# Altium schematic sheet DIn_Isolator.SchDoc
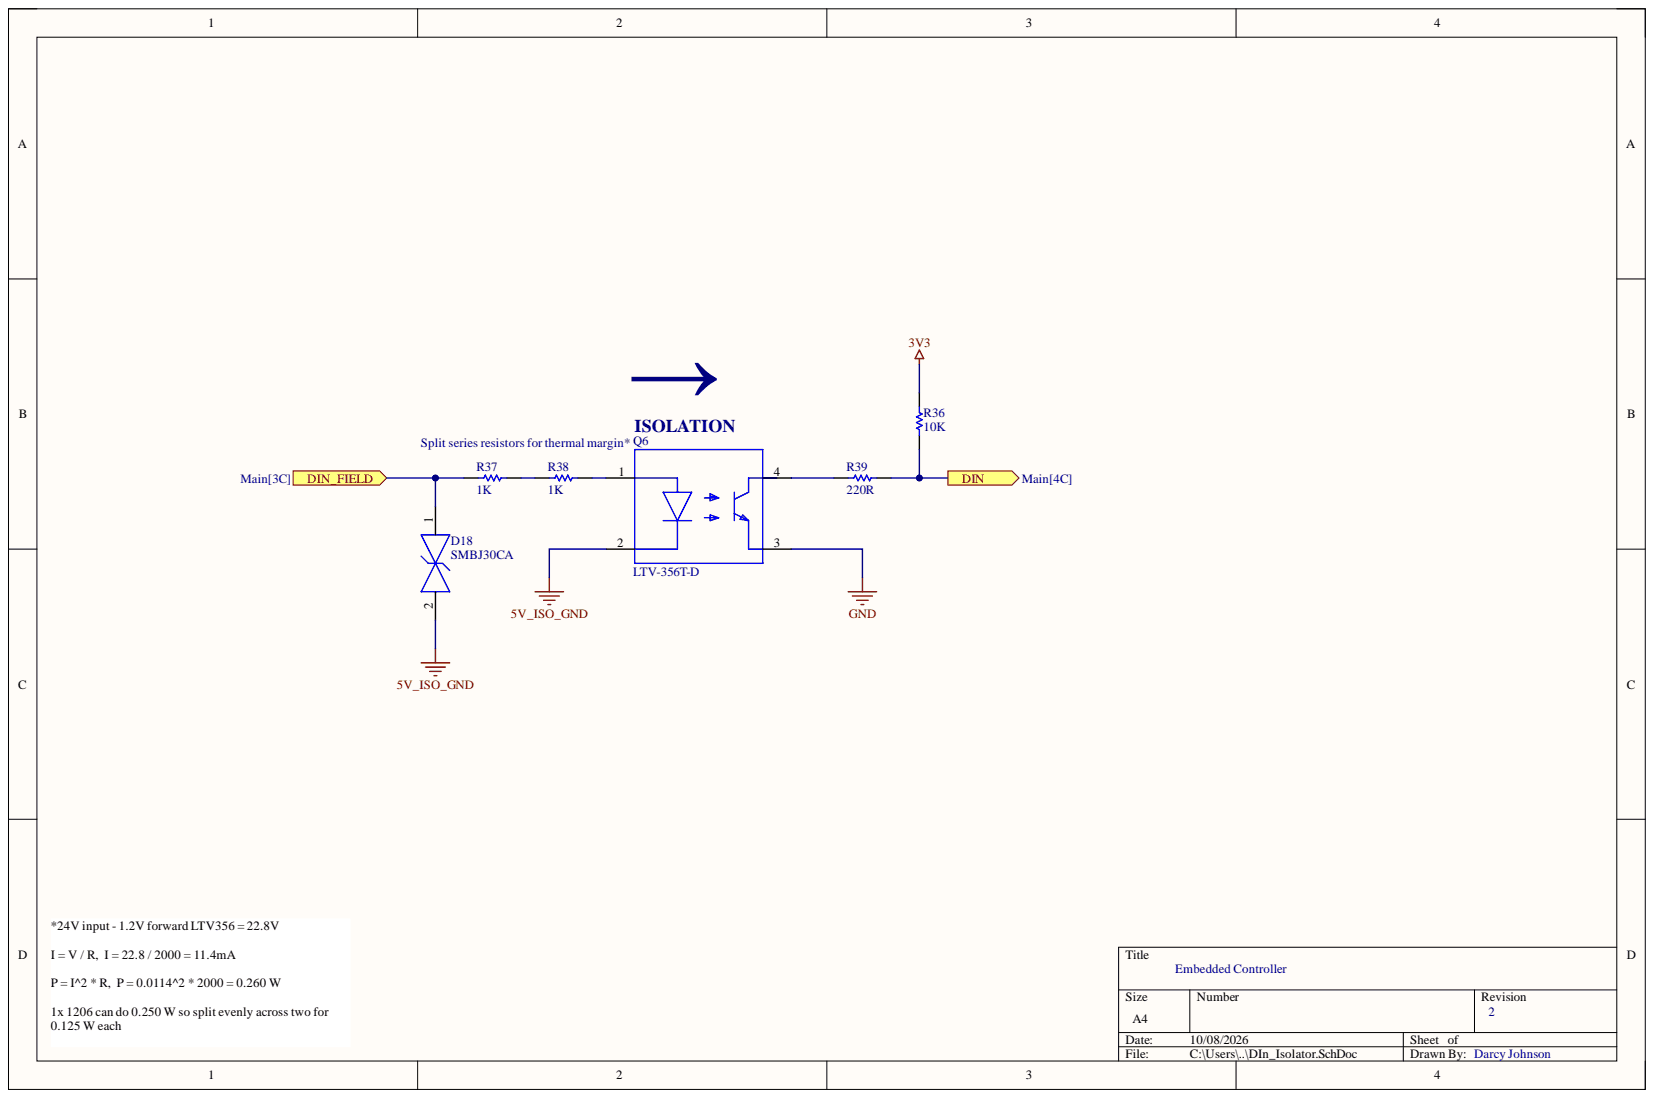
[diagram p0: DIn_Isolator.SchDoc]

## Parts
D18  SMBJ30CA  [FP-SMBJ30CAHE3-52-MFG]
Q6  LTV-356T-D  [FP-LTV-356T-D-MFG]
R36  10K  [RESC1609X55X30LL15T15]
R37  1K  [RESC3216X70X50ML20T25]
R38  1K  [RESC3216X70X50ML20T25]
R39  220R  [RESC1608X55X30LL15T15]

## Ports
DIN, DIN_FIELD

## Nets
3V3: R36.1
5V_ISO_GND: D18.2 Q6.2
GND: Q6.3
DIN: R36.2 R39.2 ; port DIN
DIN_FIELD: D18.1 R37.1 ; port DIN_FIELD
NetQ6_1: Q6.1 R38.2
NetQ6_4: Q6.4 R39.1
NetR37_2: R37.2 R38.1

## Library data of the parts
D18 = CMP-02577-000018-1: TVS DIODE 30V 48.4V DO214AA; Manufacturer: Vishay Semiconductor Diodes Division; Manufacturer Part Number: SMBJ30CAHE3/52; Automotive Grade: Grade 0; Breakdown Voltage: 33.3V; Direction: Bidirectional; Max Junction Temp: +150°C; Number Of Channels: 1; Peak Pulse Current: 12.4A; Peak Pulse Power: 600W; Standoff Height: 0mm; Automotive: Yes; Category: Diode; Clamping Voltage: 48.4V; Component Kind: Standard; ComponentLink2Description: Datasheet; ComponentLink2URL: http://www.vishay.com/docs/88392/smbj.pdf; Device Class L1: Circuit Protection; Device Class L2: TVS Diodes; Device Class L3: unset; Digikey Description: TVS DIODE 30V 48.4V DO214AA; Footprint Url: http://www.vishay.com/docs/95401/smb.pdf; Height: 2.44mm; Lead Free: Yes; Library Name: Altium Content Vault; Mouser Description: Diode TVS Single Bi-Dir 30V 600W 2-Pin DO-214AA T/R; Octopart: https://octopart.com/smbj30cahe3%2F52-vishay-43725255; Package: DO-214AA; Reverse Standoff Voltage: 30V; RoHS: TRUE; Temperature: -55°C to +150°C; Temperature Range High: +150°C; Temperature Range Low: -55°C
Q6 = CMP-22018-000003-1: OPTOCPL 1 CH TRAN OUT SMT; Manufacturer: Lite-On; Collector Emitter Voltage: 80V; Emiiter Collector Voltage: 6V; Forward Current: 20mA; Forward Voltage: 1.2V; Isolation Voltage: 3750Vrms; Max Current Transfer Ratio: 600%; Max Junction Temp: +125°C; Min Current Transfer Ratio: 300%; Power Dissipation: 170mW; Standoff Height: 0mm; Automotive: No; Category: Trans; Component Kind: Standard; ComponentLink2Description: Datasheet; ComponentLink2URL: https://ve.mouser.com/datasheet/2/239/LTV-356T%20series%20201610-1139805.pdf; Device Class L1: Optoelectronics; Device Class L2: Optocouplers; Device Class L3: unset; Digikey Description: OPTOCPL 1 CH TRAN OUT SMT; Height: 2.4mm; Lead Free: Yes; Library Name: Altium Content Vault; Manufacturer Part Number: LTV-356T-D; Octopart: https://octopart.com/ltv-356t-d-lite-on-11764243; Package: LTV-356T-D; Reverse Voltage: 6V; RoHS: TRUE; Temperature: -55°C to +110°C; Temperature Range High: +110°C; Temperature Range Low: -55°C
R36 = CMP-1012-00623-3: Chip Resistor, 10 KOhm, +/- 1%, 0.1 W, -55 to 155 degC, 0603 (1608 Metric), RoHS, Tape and Reel; Manufacturer: Panasonic; Case\/Package: 0603; Max Power Dissipation: 100mW; Resistance: 10KOhm; Temperature Coefficient: 100ppm\/degreesC; Voltage Rating: 75V; Case Code (Imperial): 0603; Case Code (Metric): 1608; Component Kind: Standard; Composition: ThickFilm; Contact Plating: Tin; Datasheet URL: https://industrial.panasonic.com/cdbs/www-data/pdf/RDA0000/AOA0000C304.pdf; Datasheet Version: 02/2017; Depth: 0.8mm; Height: 0.45mm; Lead Free: LeadFree; Length: 1.6mm; Library Name: Altium Content Vault; Manufacturer URL: http://www.panasonic.com/; Max Operating Temperature: 155degC; Military Standard: Not; Min Operating Temperature: -55degC; Mount: SurfaceMount; Mounting Technology: SurfaceMount; Number of Pins: 2; Number of Terminations: 2; Package Description: 2-Pin Surface Mount Device, Body 1.6 x 0.85 mm; Package Quantity: 1; Package Reference: 603; Packaging: TapeandReel; Power Rating: 100mW; Radiation Hardening: No; REACH SVHC: NoSVHC; RoHSCompliant: true; Termination: SMD/SMT; Tolerance: 1%; Voltage Rating (DC): 75V; Width: 0.031inch
R37 R38 = CMP-2003-04406-1: Manufacturer: Panasonic; Part Number: ERA8AEB102V; Component Kind: Standard; Library Name: Altium Content Vault
R39 = CMP-2000-00335-2: Chip Resistor, 220 Ohm, +/- 1%, 0.1 W, -55 to 155 degC, 0603 (1608 Metric), RoHS, Tape and Reel; Case\Package: 0603; Power Rating: 100 mW; Resistance: 22 Ohm; Voltage Rating: 50 V; Case Code (Imperial): 0603; Case Code (Metric): 1608; Component Kind: Standard; ComponentLink1Description: Manufacturer URL; ComponentLink1URL: http://www.panasonic.com/; ComponentLink2Description: Datasheet; ComponentLink2URL: http://industrial.panasonic.com/cdbs/www-data/pdf/RDA0000/AOA0000C86.pdf; DatasheetVersion: Apr-15; Height: 0.45 mm; Length: 1.6 mm; Library Name: Altium Content Vault; Max Operating Temperature: 155 degC; Min Operating Temperature: -55 degC; Mount: Surface Mount; Package Quantity: 5000; PackageDescription: 2-Pin Surface Mount Device, Body 1.6 x 0.85 mm; PackageReference: 0603; Packaging: Tape and Reel; Pins: 2; REACH SVHC: No SVHC; RoHSCompliant: true; Tolerance: 1%; Voltage Rating (DC): 50 V; Width: 0.8 mm
